# Altium schematic sheet GR_Alim.SchDoc
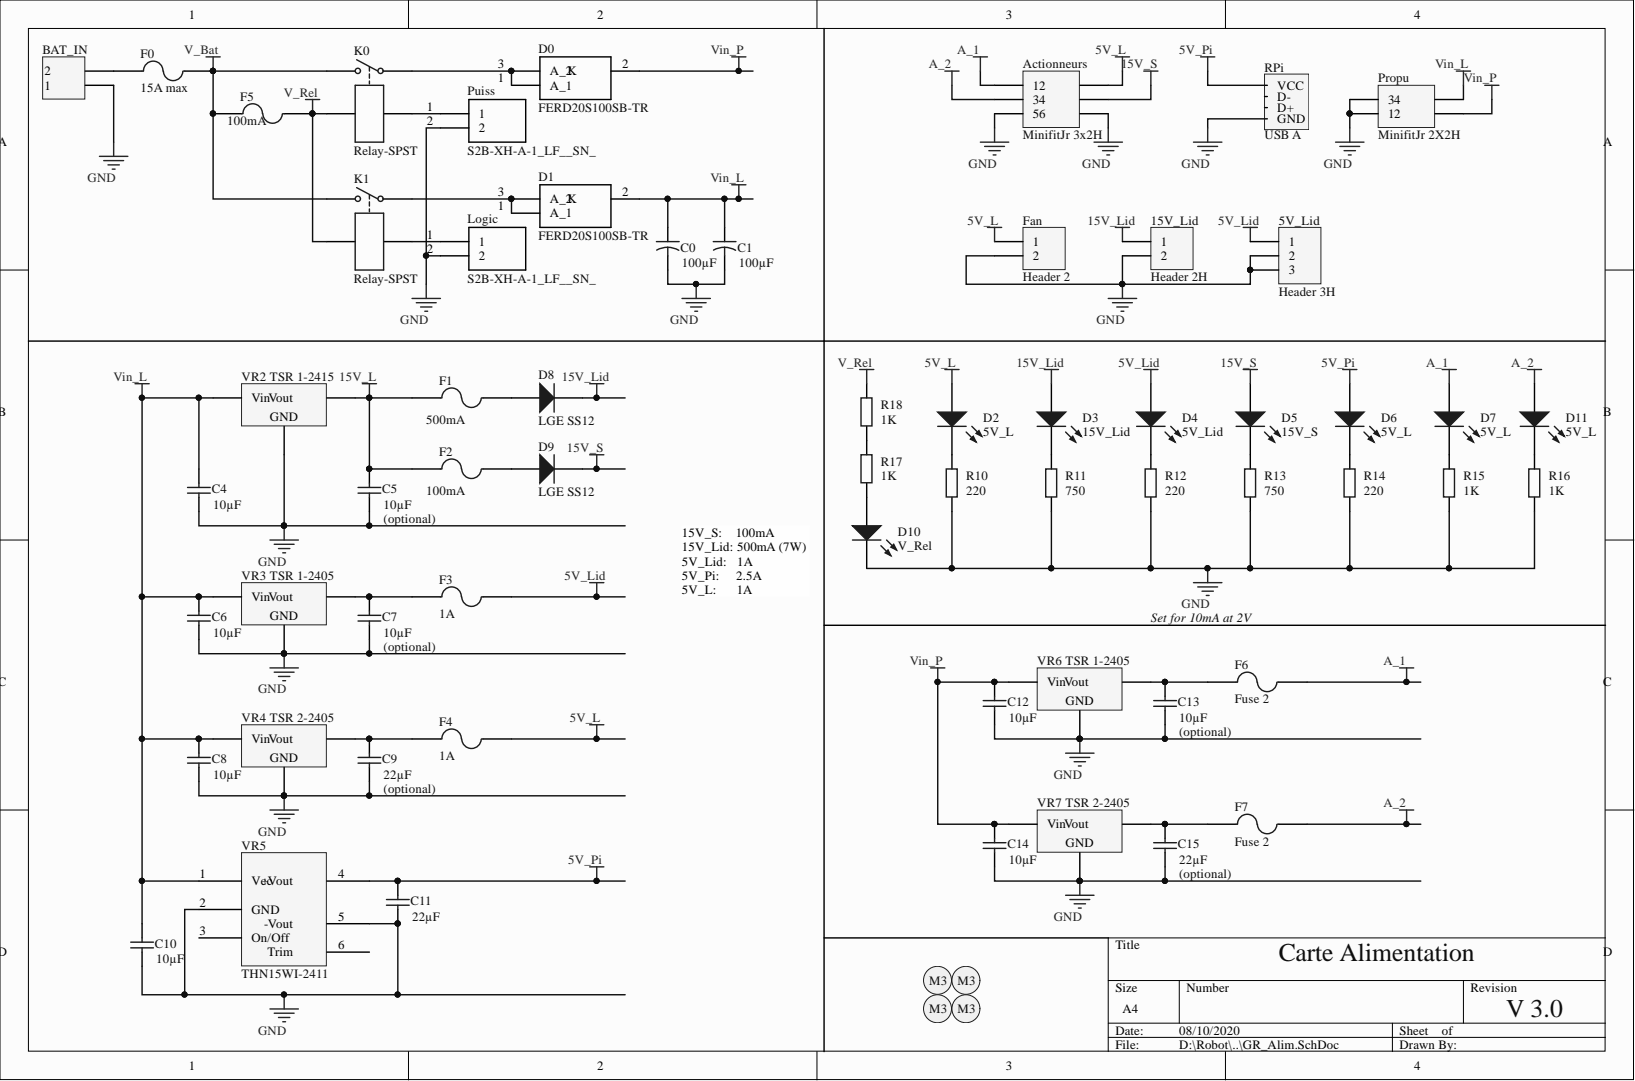
[diagram p0: GR_Alim.SchDoc]

## Parts
5V_Lid  Header 3H  [S3B-XH-A]
15V_Lid  Header 2H  [S2B-XH-A]
Actionneurs  MinifitJr 3x2H  [MOLEX_MINIFITJR_3X2H]
BAT_IN  Battery Onboard Solder  [Battery SALE]  (value not drawn)
C0  value 100µF  [Condensateur]  (hidden comment: Cap2)
C1  value 100µF  [Condensateur]  (hidden comment: Cap2)
C4  value 10µF  [Capacitor 1206]  (hidden comment: Cap)
C5  (optional)  value 10µF  [Capacitor 1206]
C6  value 10µF  [Capacitor 1206]  (hidden comment: Cap)
C7  (optional)  value 10µF  [Capacitor 1206]
C8  value 10µF  [Capacitor 1206]  (hidden comment: Cap)
C9  (optional)  value 22µF  [Capacitor 1206]
C10  value 10µF  [Capacitor 1206]  (hidden comment: Cap)
C11  value 22µF  [Capacitor 1206]  (hidden comment: Cap)
C12  value 10µF  [Capacitor 1206]  (hidden comment: Cap)
C13  (optional)  value 10µF  [Capacitor 1206]
C14  value 10µF  [Capacitor 1206]  (hidden comment: Cap)
C15  (optional)  value 22µF  [Capacitor 1206]
D0  FERD20S100SB-TR  [FERD20H100SBTR]
D1  FERD20S100SB-TR  [FERD20H100SBTR]
D2  5V_L  [KP-3216SEC]
D3  15V_Lid  [KP-3216SEC]
D4  5V_Lid  [KP-3216SEC]
D5  15V_S  [KP-3216SEC]
D6  5V_L  [KP-3216SEC]
D7  5V_L  [KP-3216SEC]
D8  LGE SS12  [SMB]
D9  LGE SS12  [SMB]
D10  V_Rel  [KP-3216SEC]
D11  5V_L  [KP-3216SEC]
F0  15A max  [Fuse]
F1  500mA  [3.5X2.8X1.9]
F2  100mA  [3.5X2.8X1.9]
F3  1A  [3.5X2.8X1.9]
F4  1A  [3.5X2.8X1.9]
F5  100mA  [3.5X2.8X1.9]
F6  Fuse 2  [3.5X2.8X1.9]
F7  Fuse 2  [3.5X2.8X1.9]
Fan  Header 2  [HDR1X2]
K0  Relay-SPST  [Relay]
K1  Relay-SPST  [Relay]
Logic  S2B-XH-A-1_LF__SN_  [S2B-XH-A]
Propu  MinifitJr 2X2H  [MOLEX_MINIFITJR_2X2H]
Puiss  S2B-XH-A-1_LF__SN_  [S2B-XH-A]
R10  value 220  [Resistor 1206]  (hidden comment: Res2)
R11  value 750  [Resistor 1206]  (hidden comment: Res2)
R12  value 220  [Resistor 1206]  (hidden comment: Res2)
R13  value 750  [Resistor 1206]  (hidden comment: Res2)
R14  value 220  [Resistor 1206]  (hidden comment: Res2)
R15  value 1K  [Resistor 1206]  (hidden comment: Res2)
R16  value 1K  [Resistor 1206]  (hidden comment: Res2)
R17  value 1K  [Resistor 1206]  (hidden comment: Res2)
R18  value 1K  [Resistor 1206]  (hidden comment: Res2)
RPi  USB A  [USB Connector]
S1  Screw M3  [Screw M3]  (value not drawn)
S2  Screw M3  [Screw M3]  (value not drawn)
S3  Screw M3  [Screw M3]  (value not drawn)
S4  Screw M3  [Screw M3]  (value not drawn)
VR2  TSR 1-2415  [TRS - Both]
VR3  TSR 1-2405  [TRS - Both]
VR4  TSR 2-2405  [TRS - Both]
VR5  THN15WI-2411  [TRACO_1x1inch]
VR6  TSR 1-2405  [TRS - Both]
VR7  TSR 2-2405  [TRS - Both]

## Nets
5V_L: Actionneurs.2 D2.1 F4.2 Fan.1
5V_LID: 5V_Lid.1 D4.1 F3.2
5V_PI: C11.2 D6.1 RPi.1(VCC) VR5.4
15V_L: C5.2 F1.1 F2.1 VR2.3
15V_LID: 15V_Lid.1 D3.1 D8.2
15V_S: Actionneurs.4 D5.1 D9.2
A_1: Actionneurs.1 D7.1 F6.2
A_2: Actionneurs.3 D11.1 F7.2
GND: 5V_Lid.2 5V_Lid.3 15V_Lid.2 Actionneurs.5 Actionneurs.6 BAT_IN.1 C0.2 C1.2 C4.1 C5.1 C6.1 C7.1 C8.1 C9.1 C10.1 C11.1 C12.1 C13.1 C14.1 C15.1 D10.2 Fan.2 Logic.2 Propu.1 Propu.3 Puiss.2 R10.1 R11.1 R12.1 R13.2 R14.2 R15.2 R16.2 RPi.4(GND) VR2.2 VR3.2 VR4.2 VR5.2(GND) VR5.5 VR6.2 VR7.2
V_BAT: F0.2 F5.1 K0.2 K1.2
V_REL: F5.2 K0.4 K1.4 R18.1
VIN_L: C0.1 C1.1 C4.2 C6.2 C8.2 C10.2 D1.2 Propu.4 VR2.1 VR3.1 VR4.1 VR5.1(Vcc)
VIN_P: C12.2 C14.2 D0.2 Propu.2 VR6.1 VR7.1
NetBAT_IN_2: BAT_IN.2 F0.1
NetC7_2: C7.2 F3.1 VR3.3
NetC9_2: C9.2 F4.1 VR4.3
NetC13_2: C13.2 F6.1 VR6.3
NetC15_2: C15.2 F7.1 VR7.3
NetD0_1: D0.1 D0.3 K0.1
NetD1_1: D1.1 D1.3 K1.1
NetD2_2: D2.2 R10.2
NetD3_2: D3.2 R11.2
NetD4_2: D4.2 R12.2
NetD5_2: D5.2 R13.1
NetD6_2: D6.2 R14.1
NetD7_2: D7.2 R15.1
NetD8_1: D8.1 F1.2
NetD9_1: D9.1 F2.2
NetD10_1: D10.1 R17.2
NetD11_2: D11.2 R16.1
NetK0_3: K0.3 Puiss.1
NetK1_3: K1.3 Logic.1
NetR17_1: R17.1 R18.2

Not connected: RPi 2 3(D+); VR5 3(On/Off) 6

## Library data of the parts
5V_Lid = Header 3H: Header, 3-Pin, Right Angle; Component Kind: Standard; LatestRevisionDate: 17-Jul-2002; LatestRevisionNote: Re-released for DXP Platform.; Library Name: Miscellaneous Connectors.IntLib; Signal Integrity: Connector; Publisher: Altium Limited
15V_Lid = Header 2H: Header, 2-Pin, Right Angle; Component Kind: Standard; LatestRevisionDate: 17-Jul-2002; LatestRevisionNote: Re-released for DXP Platform.; Library Name: Miscellaneous Connectors.IntLib; Signal Integrity: Connector; Publisher: Altium Limited
Actionneurs = Header 3X2H: Header, 3-Pin, Dual row, Right Angle; Component Kind: Standard; LatestRevisionDate: 17-Jul-2002; LatestRevisionNote: Re-released for DXP Platform.; Library Name: Miscellaneous Connectors.IntLib; Signal Integrity: Connector; Publisher: Altium Limited
BAT_IN Fan = Header 2: Header, 2-Pin; Component Kind: Standard; LatestRevisionDate: 17-Jul-2002; LatestRevisionNote: Re-released for DXP Platform.; Library Name: Miscellaneous Connectors.IntLib; Signal Integrity: Connector; Publisher: Altium Limited
C0 C1 = Cap2: Capacitor; Component Kind: Standard; Library Name: Miscellaneous Devices.IntLib; PackageDescription: Capacitor; 2 Leads; PackageReference: CAPR5-4X5; Published: 23-Sep-2002; Signal Integrity: Cap; Simulation: CAP; Publisher: Altium Limited
C4-C15 = Cap: Capacitor; Component Kind: Standard; LatestRevisionDate: 17-Jul-2002; LatestRevisionNote: Re-released for DXP Platform.; Library Name: Miscellaneous Devices.IntLib; PackageDescription: Radial Cap, Thru-Hole; 2 Leads; 0.3 in Pin Spacing; PackageReference: RAD-0.3; Published: 8-Jun-2000; Signal Integrity: Cap; Simulation: CAP; Publisher: Altium Limited
D0 D1 = FERD20S100SB-TR: Diode; Manufacturer_Name: STMicroelectronics; Arrow Part Number: FERD20S100SB-TR; Arrow Price/Stock: https://www.arrow.com/en/products/ferd20s100sb-tr/stmicroelectronics; Component Kind: Standard; Datasheet Link: https://www.mouser.com/datasheet/2/389/dm00272110-1798832.pdf; Height: 2.4mm; Manufacturer_Part_Number: FERD20S100SB-TR; Mouser Part Number: 511-FERD20S100SB-TR; Mouser Price/Stock: https://www.mouser.co.uk/ProductDetail/STMicroelectronics/FERD20S100SB-TR?qs=u4fy%2FsgLU9N8IipzyIKZ6Q%3D%3D
D2-D7 D10 D11 = LED2: Typical RED, GREEN, YELLOW, AMBER GaAs LED; Component Kind: Standard; LatestRevisionDate: 17-Jul-2002; LatestRevisionNote: Re-released for DXP Platform.; Library Name: Miscellaneous Devices.IntLib; PackageDescription: SM LED; 2 Flat Leads; PackageReference: 3.2X1.6X1.1; PackageVersion: Feb-2002; Published: 8-Jun-2000; Simulation: LED2; Publisher: Altium Limited
D8 D9 = Diode: Default Diode; Code_JEDEC: DO-214-AB; Component Kind: Standard; LatestRevisionDate: 17-Jul-2002; LatestRevisionNote: Re-released for DXP Platform.; Library Name: Miscellaneous Devices.IntLib; PackageDescription: DO-214-AB/SMC; 2 C-Bend Leads; Body 7.9 x 5.9 mm, inc. leads (LxW); PackageReference: SMC; PackageVersion: Sep-1996; Published: 8-Jun-2000; Signal Integrity: Diode; Simulation: DIODE; Publisher: Altium Limited
F0 = Fuse 2: Main Fuse; Component Kind: Standard; LatestRevisionDate: 17-Jul-2002; LatestRevisionNote: Re-released for DXP Platform.; Library Name: Miscellaneous Devices.IntLib; PackageDescription: Thru-Hole; 2 Leads; PackageReference: PIN-W2/E2.8; Published: 8-Jun-2000; Simulation: FUSE; Publisher: Altium Limited
F1 F3-F7 = Fuse 2: Fuse; Component Kind: Standard; LatestRevisionDate: 17-Jul-2002; LatestRevisionNote: Re-released for DXP Platform.; Library Name: Miscellaneous Devices.IntLib; PackageDescription: Thru-Hole; 2 Leads; PackageReference: PIN-W2/E2.8; Published: 8-Jun-2000; Simulation: FUSE; Publisher: Altium Limited
F2 = Fuse 2: MICROSMD010F-2; Component Kind: Standard; LatestRevisionDate: 17-Jul-2002; LatestRevisionNote: Re-released for DXP Platform.; Library Name: Miscellaneous Devices.IntLib; PackageDescription: Thru-Hole; 2 Leads; PackageReference: PIN-W2/E2.8; Published: 8-Jun-2000; Simulation: FUSE; Publisher: Altium Limited
K0 K1 = Relay-SPST: Single-Pole Single-Throw Relay; Component Kind: Standard; LatestRevisionDate: 17-Jul-2002; LatestRevisionNote: Re-released for DXP Platform.; Library Name: Miscellaneous Devices.IntLib; PackageReference: MODULE4; Published: 24-Mar-1999; Simulation: SPSTRELAY; Publisher: Altium Limited
Logic Puiss = S2B-XH-A-1_LF__SN_: Connector; Manufacturer_Name: JST (JAPAN SOLDERLESS TERMINALS); Manufacturer_Part_Number: S2B-XH-A-1(LF)(SN); Arrow Part Number: S2B-XH-A-1(LF)(SN); Component Kind: Standard; Datasheet Link: http://www.jst-mfg.com/product/pdf/eng/eXH.pdf; Height: 6.1mm; Library Name: SamacSys.SchLib
Propu = Header 2X2H: Header, 2-Pin, Dual row, Right Angle; Component Kind: Standard; LatestRevisionDate: 17-Jul-2002; LatestRevisionNote: Re-released for DXP Platform.; Library Name: Miscellaneous Connectors.IntLib; Signal Integrity: Connector; Publisher: Altium Limited
R10-R18 = Res2: Resistor; Component Kind: Standard; LatestRevisionDate: 17-Jul-2002; LatestRevisionNote: Re-released for DXP Platform.; Library Name: Miscellaneous Devices.IntLib; PackageDescription: Axial Device, Thru-Hole; 2 Leads; 0.4 in Pin Spacing; PackageReference: AXIAL-0.4; Published: 8-Jun-2000; Signal Integrity: Res; Simulation: RESISTOR; Publisher: Altium Limited
RPi = USB_A: Component Kind: Standard; Library Name: Robot_2020.SchLib
VR2-VR4 VR6 VR7 = Volt Reg: Voltage Regulator; Component Kind: Standard; LatestRevisionDate: 29-May-2009; LatestRevisionNote: IPC-7351 Footprint Added.; Library Name: Miscellaneous Devices.IntLib; PackageDescription: 3-Pin Transistor Outline Package 2.54 mm Pitch, 15.1 mm Lead Span; PackageReference: D2PAK; Published: 24-Mar-1999; Publisher: Altium Limited
VR5 = TEN60N: TRACO THN15WI-2411; Component Kind: Standard; LatestRevisionDate: 17-Jul-2002; LatestRevisionNote: Re-released for DXP Platform.; Library Name: Robot_2020.SchLib; Signal Integrity: Connector; Publisher: Altium Limited
S1-S4 = Screw M3: Component Kind: Standard; Library Name: Robot_2020.SchLib
